# Altium schematic sheet 701C Power Button.SchDoc
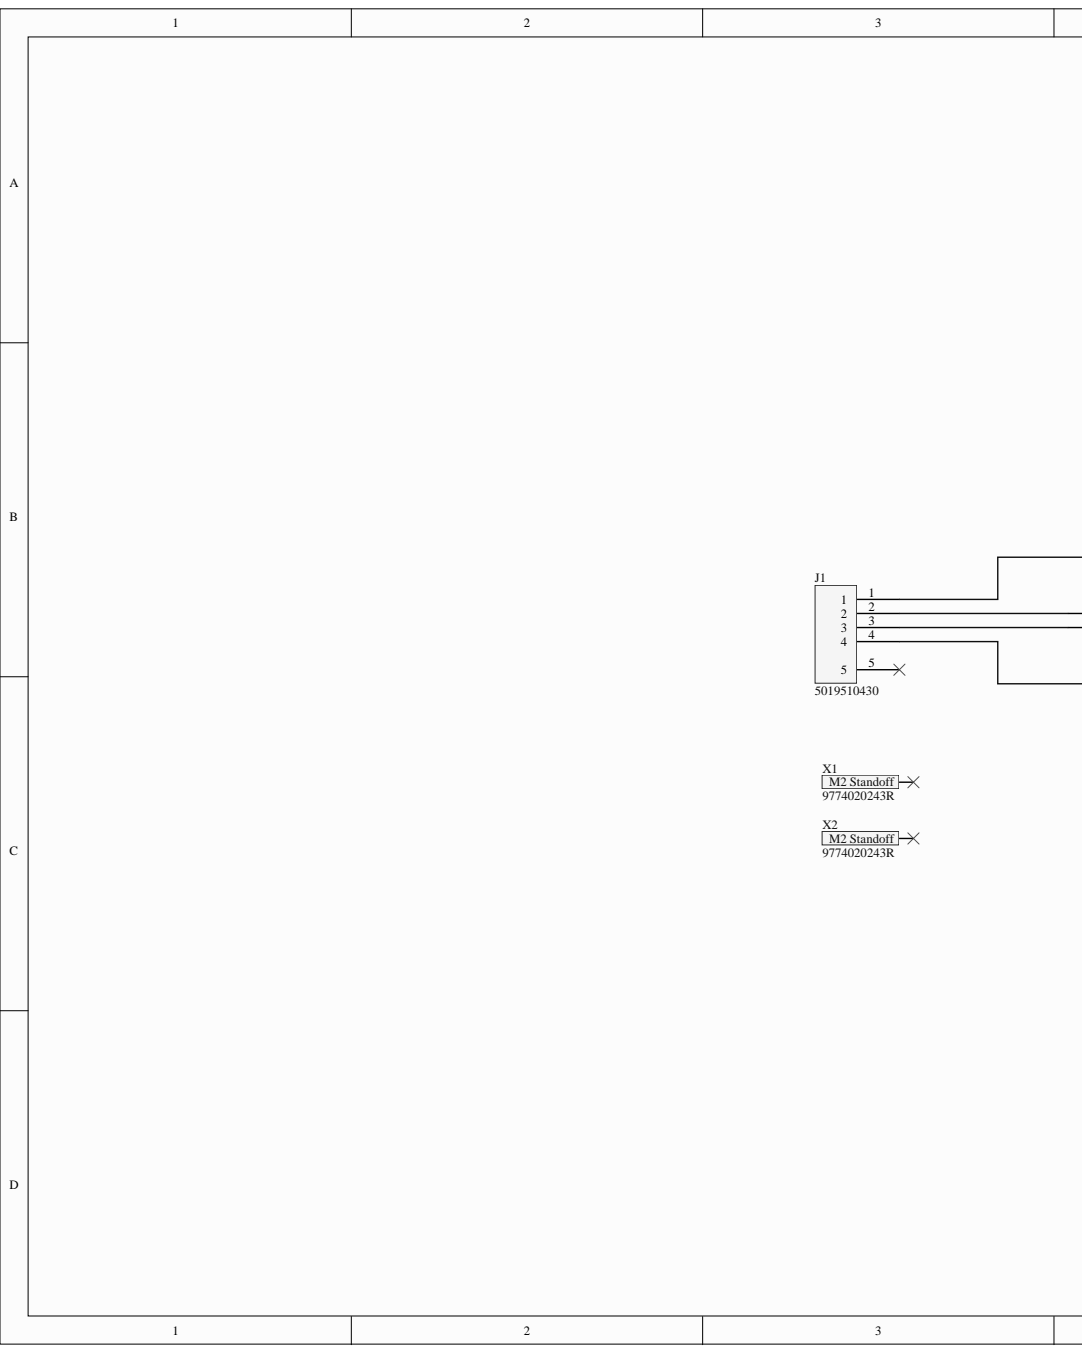
[diagram p0: 701C Power Button.SchDoc, left]
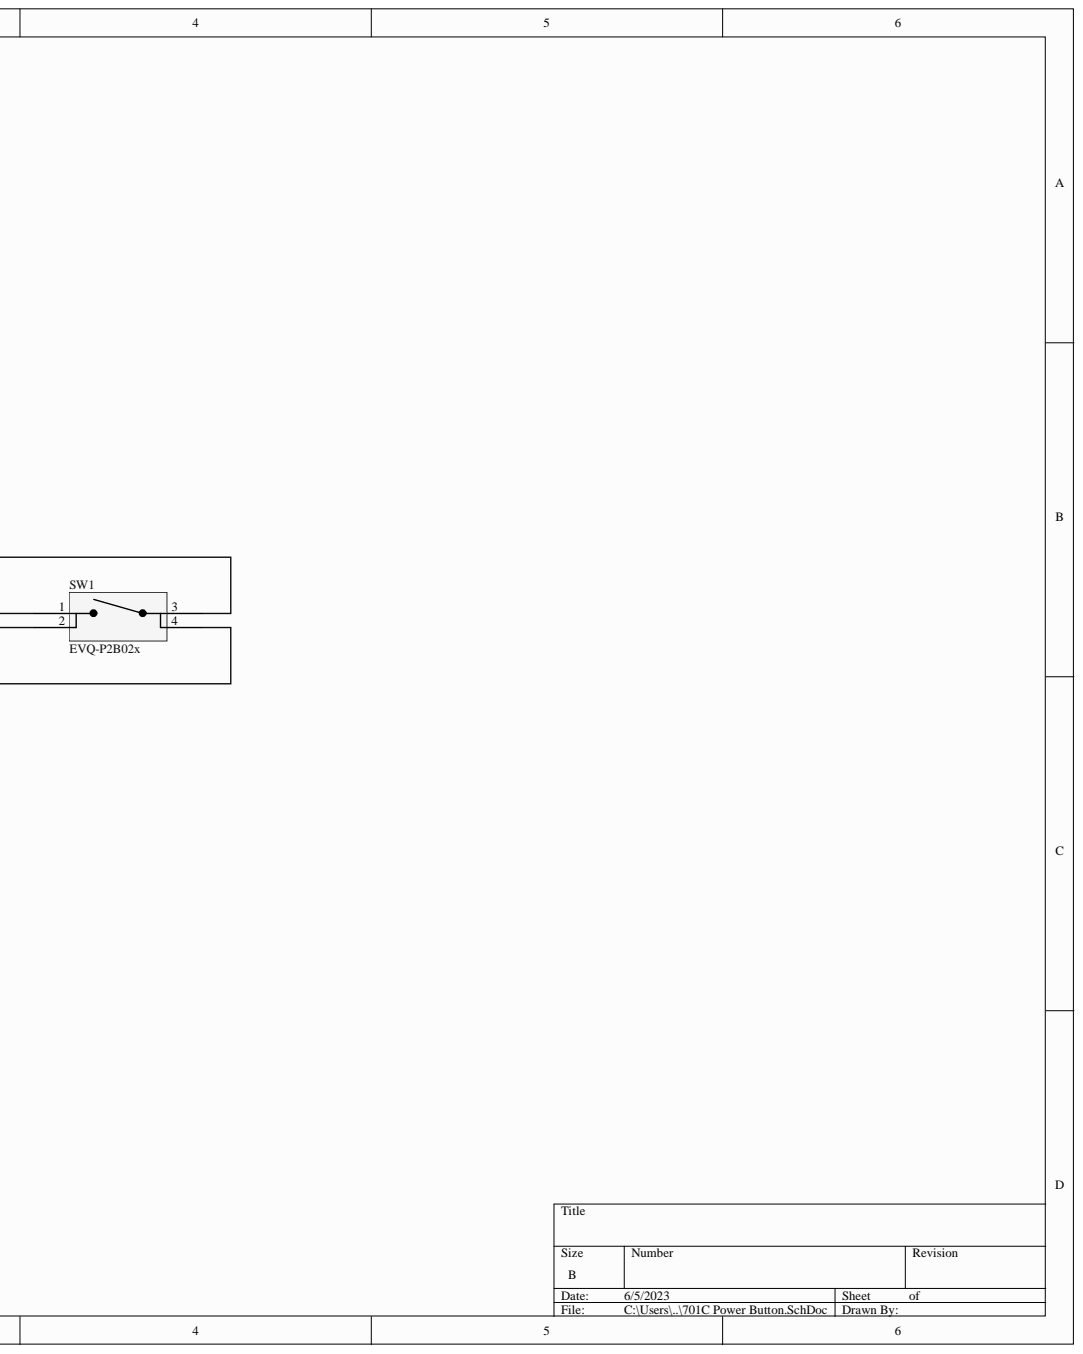
[diagram p1: 701C Power Button.SchDoc, right]

## Parts
J1  5019510430  [5019510430]
SW1  EVQ-P2B02x  [EVQ-P2B02x]
X1  9774020243R  [9774020243R]
X2  9774020243R  [9774020243R]

## Nets
NetJ1_1: J1.1 SW1.3
NetJ1_2: J1.2 SW1.1
NetJ1_3: J1.3 SW1.2
NetJ1_4: J1.4 SW1.4

Not connected: J1 5; X1 1; X2 1

## Library data of the parts
J1 = 5019510430: 4 Position FFC, FPC Connector Contacts, Vertical - 1 Sided 0.020" (0.50mm) Surface Mount; Component Kind: Standard; Library Name: KBuchka-IntLib.SchLib
SW1 = EVQ-P2B02x: SWITCH TACTILE SPST-NO 0.02A 15V; Component Kind: Standard; Library Name: KBuchka-IntLib.SchLib
X1 X2 = 9774020243R: Component Kind: Standard; Library Name: KBuchka-IntLib.SchLib
